# Altium schematic sheet Power.SchDoc(Power)
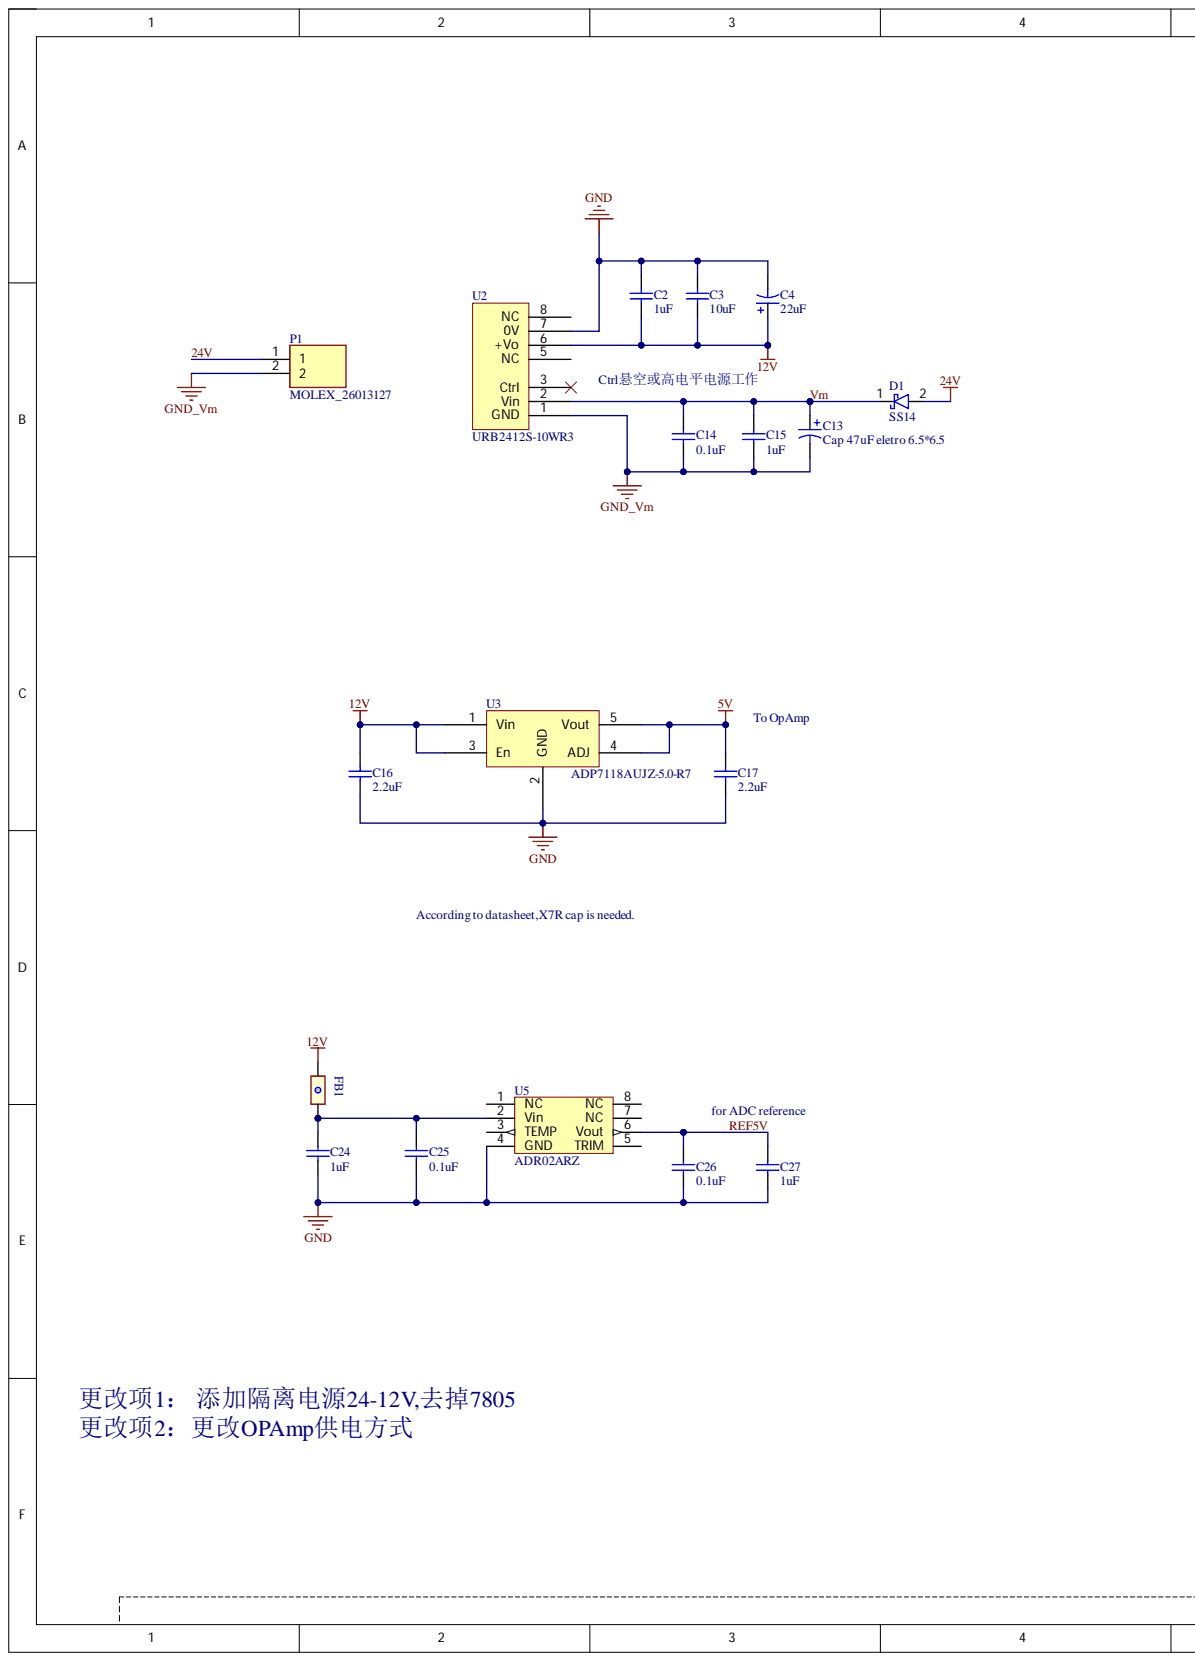
[diagram p0: Power.SchDoc, left]
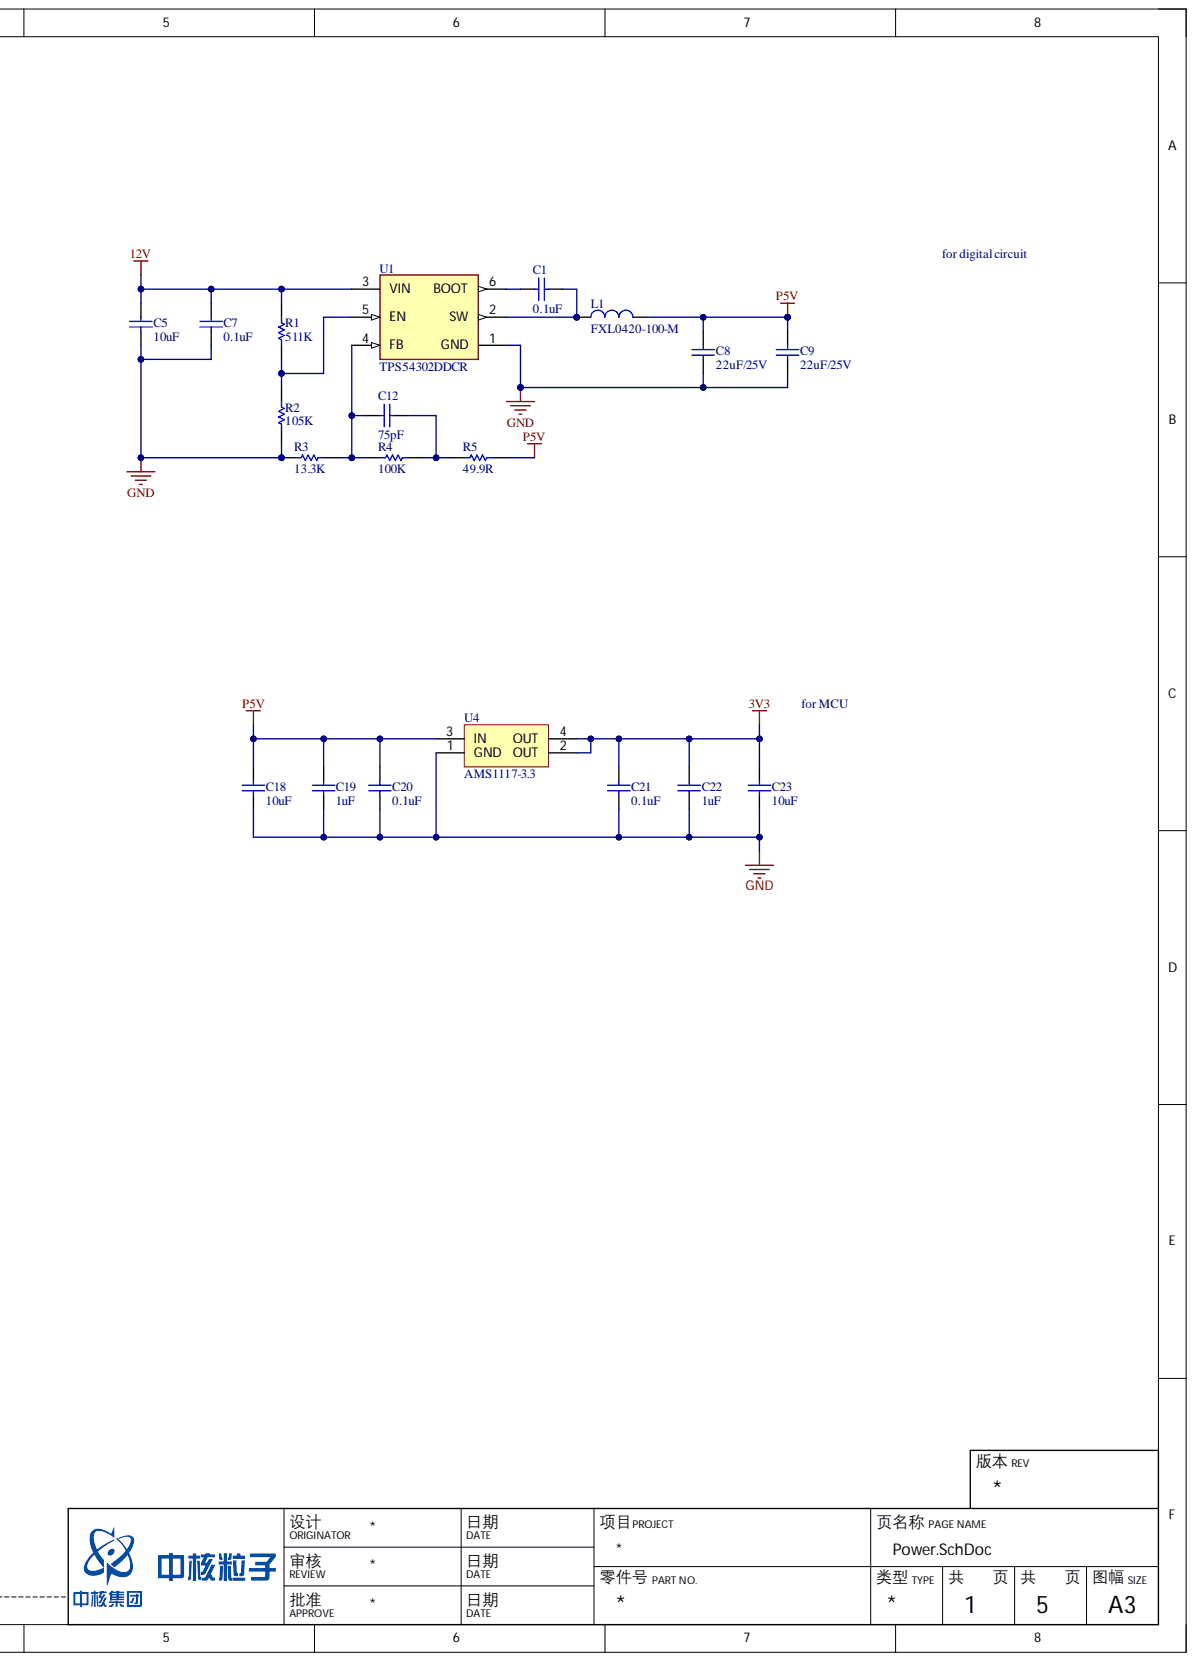
[diagram p1: Power.SchDoc, right]

## Parts
C1  0.1uF  [C0603(1608)]
C2  1uF  [C0805(2012)]
C3  10uF  [C0805(2012)]
C4  22uF  [C2312(6032)]
C5  10uF  [C0603(1608)]
C7  0.1uF  [C0603(1608)]
C8  22uF/25V  [C1206(3216)]
C9  22uF/25V  [C1206(3216)]
C12  75pF  [C0402(1005)]
C13  Cap 47uF eletro 6.5*6.5  [CAP6.6x6.6mm]
C14  0.1uF  [C0603(1608)]
C15  1uF  [C0603(1608)]
C16  2.2uF  [C0805(2012)]
C17  2.2uF  [C0805(2012)]
C18  10uF  [C0603(1608)]
C19  1uF  [C0603(1608)]
C20  0.1uF  [C0603(1608)]
C21  0.1uF  [C0603(1608)]
C22  1uF  [C0603(1608)]
C23  10uF  [C0603(1608)]
C24  1uF  [C0603(1608)]
C25  0.1uF  [C0603(1608)]
C26  0.1uF  [C0603(1608)]
C27  1uF  [C0603(1608)]
D1  SS14  [DIONM5327X242N]
FB1  BLM18AG102SN1D  [FB0603(1608)]  (value not drawn)
L1  FXL0420-100-M  [FXL0420-6R8-M]
P1  MOLEX_26013127  [26013127]
R1  511K  [R0402(1005)]
R2  105K  [R0402(1005)]
R3  13.3K  [C0402(1005)]
R4  100K  [R0402(1005)]
R5  49.9R  [R0402(1005)]
U1  TPS54302DDCR  [FP-DDC0006A-IPC_C]
U2  URB2412S-10WR3  [URB2412S-10WR3]
U3  ADP7118AUJZ-5.0-R7  [TSOT-23-5]
U4  AMS1117-3.3  [SOT223-4]
U5  ADR02ARZ  [SOIC8]

## Nets
3V3: C21.2 C22.2 C23.2 U4.2(OUT) U4.4(OUT)
5V: C17.2 U3.4(ADJ) U3.5(Vout)
12V: C2.1 C3.1 C4.1 C5.2 C7.2 C16.2 FB1.1 R1.2 U1.3(VIN) U2.6(+Vo) U3.1(Vin) U3.3(En)
GND: C2.2 C3.2 C4.2 C5.1 C7.1 C8.1 C9.1 C16.1 C17.1 C18.1 C19.1 C20.1 C21.1 C22.1 C23.1 C24.1 C25.1 C26.1 C27.1 R2.1 R3.1 U1.1(GND) U2.7(0V) U3.2(GND) U4.1(GND) U5.4(GND)
GND_Vm: C13.2 C14.1 C15.1 P1.2 U2.1(GND)
P5V: C8.2 C9.2 C18.2 C19.2 C20.2 L1.1 R5.2 U4.3(IN)
24V: D1.2 P1.1
REF5V: C26.2 C27.2 U5.6(Vout)
Vm: C13.1 C14.2 C15.2 D1.1 U2.2(Vin)
NetC1_1: C1.1 L1.2 U1.2(SW)
NetC1_2: C1.2 U1.6(BOOT)
NetC12_1: C12.1 R3.2 R4.1 U1.4(FB)
NetC12_2: C12.2 R4.2 R5.1
NetC24_2: C24.2 C25.2 FB1.2 U5.2(Vin)
NetR1_1: R1.1 R2.2 U1.5(EN)

Not connected: U2 3(Ctrl) 5(NC) 8(NC); U5 1(NC) 3(TEMP) 5(TRIM) 7(NC) 8(NC)

## Library data of the parts
C1 C7 C14 C20 C21 C25 C26 = Cap 0.1uF 0603-50V: ceramic 50V; 价格: ¥0.44@100; 制造商: WURTH ELEKTRONIK; 型号: 885012206095; Component Kind: Standard; Library Name: RCL_release.SchLib; 供应商及库存编号: e络盟 2495187
C2 = Cap 1uF 0805-25V: ceramic 25V; 价格: ¥0.38@250; 制造商: KEMET; 型号: C0805C105K3RACTU; Component Kind: Standard; Library Name: RCL_release.SchLib; 供应商及库存编号: e络盟 1637035
C3 = Cap 10uF 0805-25V: ceramic 25V; 价格: ¥1.44@250; 制造商: KEMET; 型号: C0805C106K3PACTU; Component Kind: Standard; Library Name: RCL_release.SchLib; 供应商及库存编号: e络盟 2491176
C4 = Cap 22uF 6032-25V: TANT 25V; 价格: ¥3.09@100; 制造商: KEMET; 型号: T491C226K025AT; Component Kind: Standard; Library Name: RCL_release.SchLib; 供应商及库存编号: e络盟 2283579
C5 C18 C23 = Cap 10uF 0603-25V: ceramic 25V; 价格: 5.86; 制造商: TDK; 型号: C1608JB1E106M080AC; Component Kind: Standard; Library Name: RCL_release.SchLib; 供应商及库存编号: Mouser 810-C1608JB1E106M08C
C8 C9 = Cap 22uF 1206-25V: 1206 [3216 公制], 22 µF, 25 V, ± 10%, X5R, GRM系列; 价格: ¥2.84@10; 制造商: MURATA; 名称: 瓷片电容; 型号: GRM31CR61E226KE15L; Component Kind: Standard; Library Name: RCL_release.SchLib; 供应商及库存编号: e络盟 1797010
C12 = Cap 75pF 0402-50V: ceramic 50V; Component Kind: Standard; Library Name: RCL_release.SchLib
C13 = Cap 47uF eletro 6.5*6.5: electro 50V panasonic EEEFT1H470AP 6.5*6.5; Component Kind: Standard; Library Name: RCL_temp.SchLib
C15 C19 C22 C24 C27 = Cap 1uF 0603-50V: ceramic 50V; 价格: ¥1.96@100; 制造商: TDK; 型号: CGA3E3X5R1H105K080AB; Component Kind: Standard; Library Name: RCL_release.SchLib; 供应商及库存编号: e络盟 2210922
C16 C17 = Cap 2.2uF 0805-25V: ceramic 25V; 价格: ¥0.414@2.5K; 制造商: KEMET; 型号: C0805C225K3RACTU; Component Kind: Standard; Library Name: RCL_release.SchLib; 供应商及库存编号: e络盟 2750815
D1 = SS14: Schottky Diode; Component Kind: Standard; Library Name: Transistor_release.SchLib
FB1 = FB-1K@100MHz 0603: FERRITE BEAD 1 KOHM 0603 1LN; 价格: ¥0.25@100; 制造商: MURATA; 名称: 磁珠; Component Kind: Standard; Library Name: RCL_release.SchLib; 供应商及库存编号: e络盟 1515671; 型号: BLM18AG102SN1D
L1 = FXL0420-100-M: 10uH 1.6A 4.2*4.4*1.8mm; Component Kind: Standard; Library Name: RCL_temp.SchLib
P1 = 26013127: Connector; Manufacturer_Name: Molex; Arrow Part Number: 1720650202; Arrow Price/Stock: https://www.arrow.com/en/products/1720650202/molex; Component Kind: Standard; Datasheet Link: https://www.molex.com/pdm_docs/sd/1720650202_sd.pdf; Height: 15.05mm; Library Name: Connector_release.SchLib; Manufacturer_Part_Number: 26013127
R1 = Res 511K 0402: Res ±1%; Component Kind: Standard; Library Name: RCL_release.SchLib
R2 = Res 105K 0402: Res ±1%; Component Kind: Standard; Library Name: RCL_release.SchLib
R3 = Res 13.3K 0402: Res ±1%; Component Kind: Standard; Library Name: RCL_release.SchLib
R4 = Res 100K 0402: Res ±1%; Component Kind: Standard; Library Name: RCL_release.SchLib
R5 = Res 49.9R 0402: Res ±1%; Component Kind: Standard; Library Name: RCL_release.SchLib
U1 = TPS54302DDCR: IC REG BUCK ADJ 3A TSOT23-6; Component Kind: Standard; Library Name: IC_release.SchLib
U2 = URB2412S-10WR3: isolated DCDC 24V-12V Mornsun; Component Kind: Standard; Library Name: IC_temp.SchLib
U3 = ADP7118AUJZ-5.0-R7: LDO to 5V,low noise 200mA; Component Kind: Standard; Library Name: IC_temp.SchLib
U5 = ADR02ARZ: Vref 5.0V±5mV; Component Kind: Standard; Library Name: IC_temp.SchLib
U4 = AMS1117-3.3: Component Kind: Standard; Description: AMS1117-3.3; Library Name: IC_release.SchLib
